# Altium schematic sheet 20-002314-00 pg2 Fixture Power.SchDoc
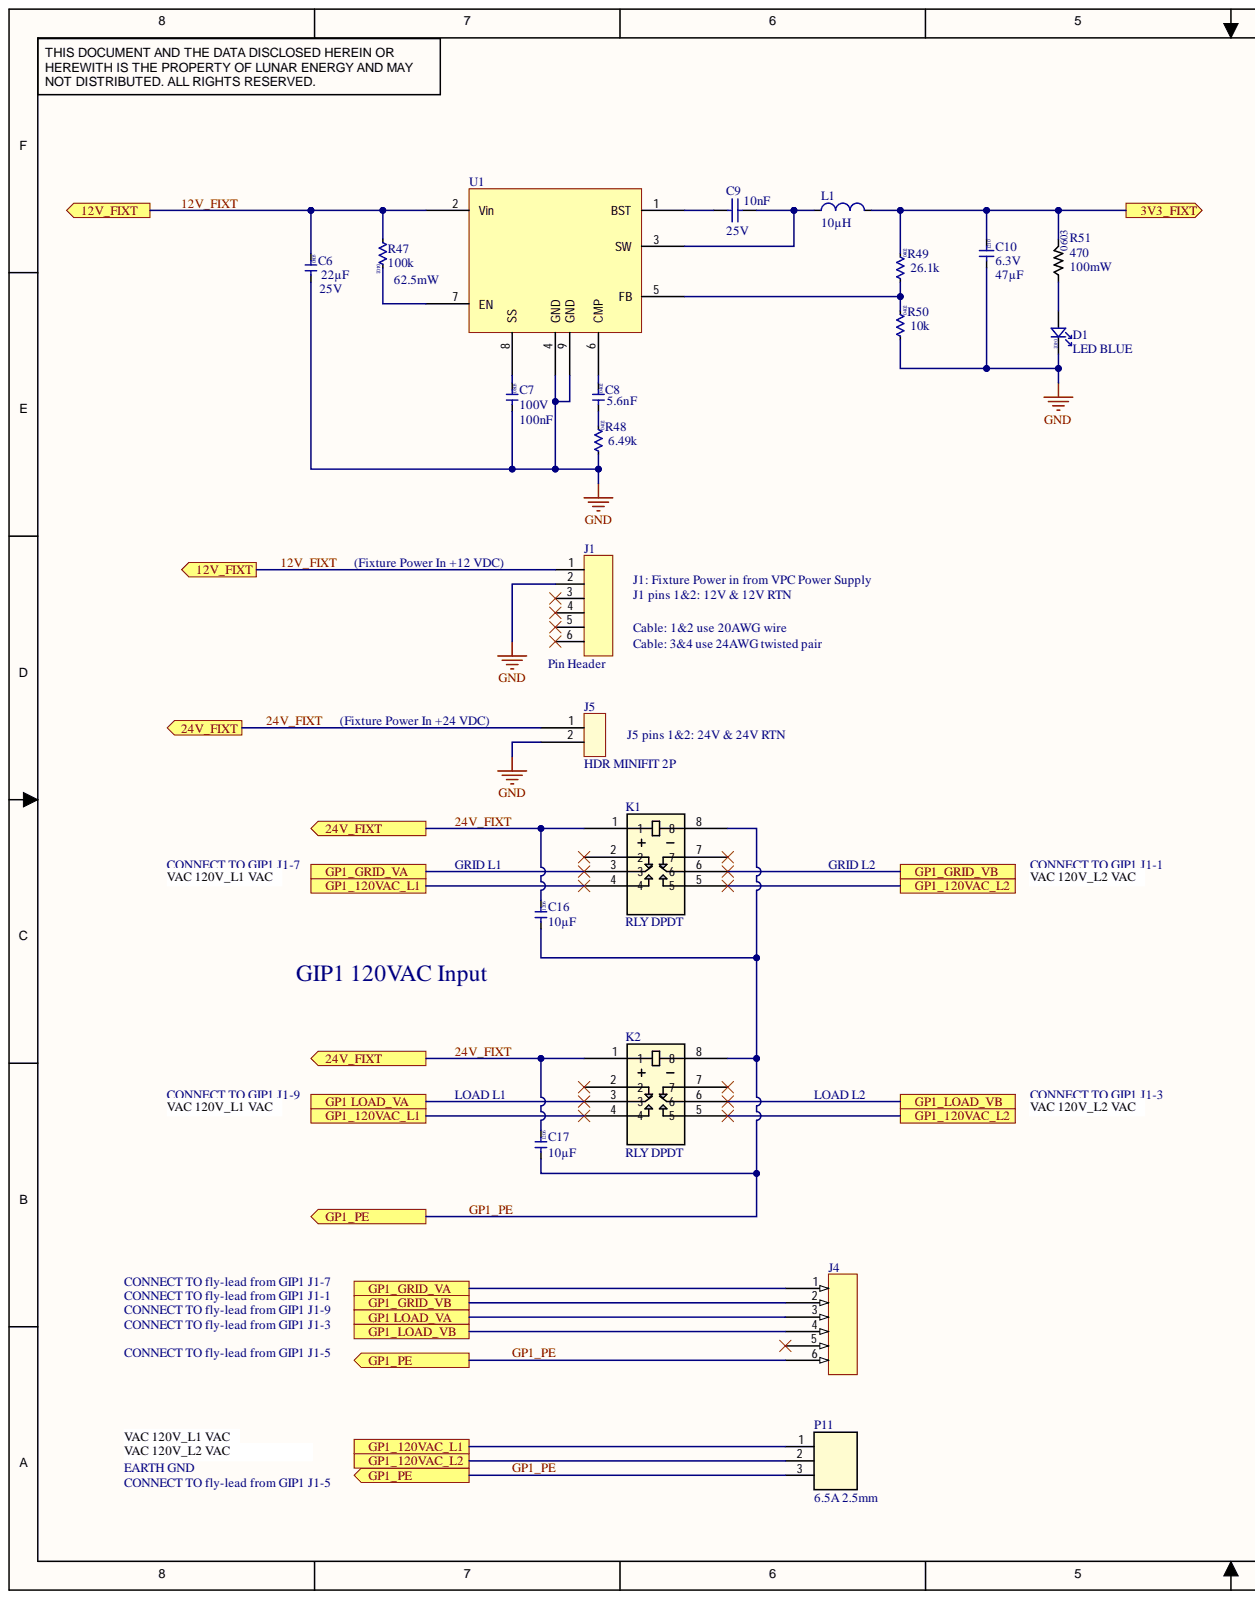
[diagram p0: 20-002314-00 pg2 Fixture Power.SchDoc, left]
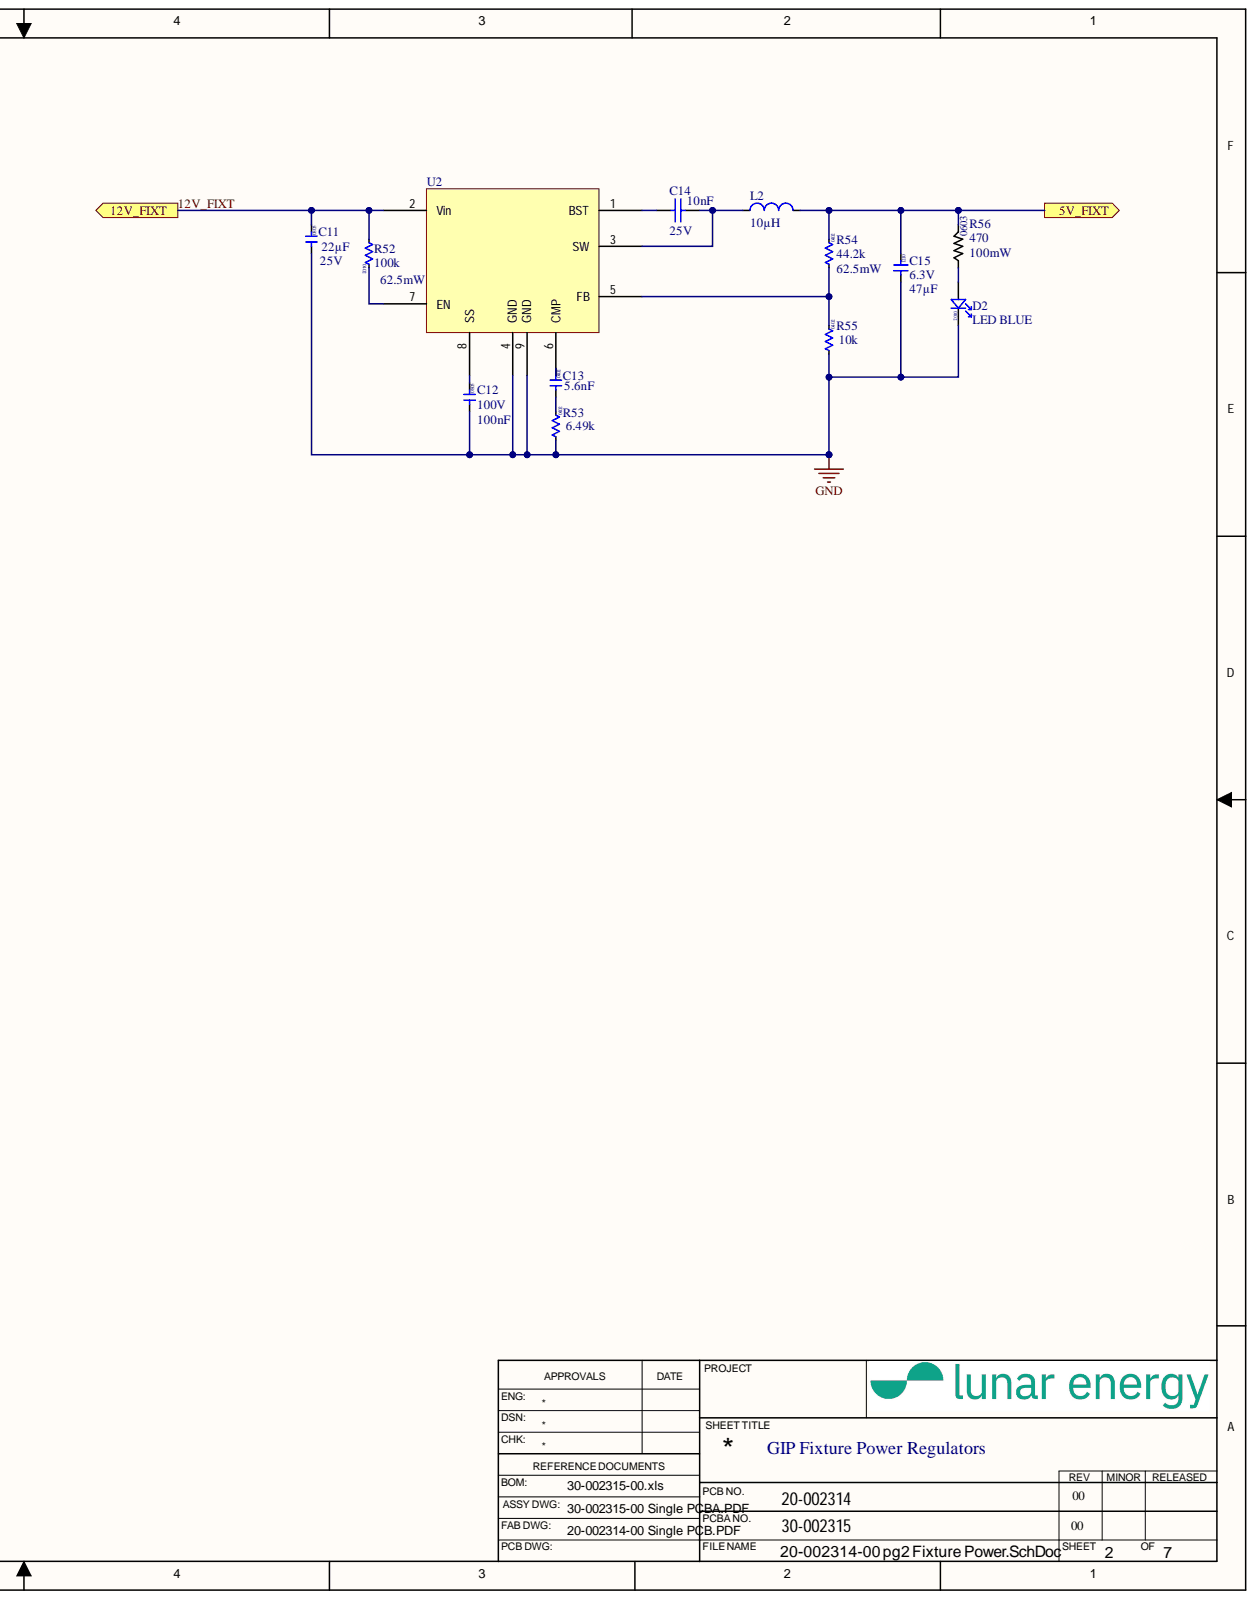
[diagram p1: 20-002314-00 pg2 Fixture Power.SchDoc, right]

## Parts
C6  value 22µF  Case/Package: 0805  Voltage Rating: 25V  [FP-CGA4J-IPC_A]  (hidden comment: GRM21BR61E226ME44L)
C7  value 100nF  Capacitance: 100nF  Rated DC Voltage (URdc): 100V  Size Code: 0805  [CAPC0805 - Lunar]  (hidden comment: GCE21BR72A104KA01L)
C8  value 5.6nF  Case/Package: 0402  [CAPC0402 - Lunar]  (hidden comment: CC0402JRX7R9BB562)
C9  value 10nF  Voltage Rating: 25V  [CAPC1005X55X10NL05]  (hidden comment: C1005X7R1E103M050BB)
C10  value 47µF  Case/Package: 1210  Rated DC Voltage (URdc): 6.3V  [FP-GCJ32E-0_4-0_3-MFG]  (hidden comment: GCJ32ER70J476KE01L)
C11  value 22µF  Case/Package: 0805  Voltage Rating: 25V  [FP-CGA4J-IPC_A]  (hidden comment: GRM21BR61E226ME44L)
C12  value 100nF  Capacitance: 100nF  Rated DC Voltage (URdc): 100V  Size Code: 0805  [CAPC0805 - Lunar]  (hidden comment: GCE21BR72A104KA01L)
C13  value 5.6nF  Case/Package: 0402  [CAPC0402 - Lunar]  (hidden comment: CC0402JRX7R9BB562)
C14  value 10nF  Voltage Rating: 25V  [CAPC1005X55X10NL05]  (hidden comment: C1005X7R1E103M050BB)
C15  value 47µF  Case/Package: 1210  Rated DC Voltage (URdc): 6.3V  [FP-GCJ32E-0_4-0_3-MFG]  (hidden comment: GCJ32ER70J476KE01L)
C16  value 10µF  Case/Package: 1206  [CAPC1206 - Lunar]  (hidden comment: CAP-1206-10U-A)
C17  value 10µF  Case/Package: 1206  [CAPC1206 - Lunar]  (hidden comment: CAP-1206-10U-A)
D1  Case/Package: 0402  Lunar Sym Name: LED BLUE  [APG1005PBC-T-5MAV-Footprint-1]  (hidden comment: D LED 0402 BLUE CLEAR SMD)
D2  Case/Package: 0402  Lunar Sym Name: LED BLUE  [APG1005PBC-T-5MAV-Footprint-1]  (hidden comment: D LED 0402 BLUE CLEAR SMD)
J1  Connector Type: Pin Header  [HDRV6W66P0X254_1X6_1524X249X838P]  (hidden comment: 0022284066)
J4  15-24-6061  [15-24-6061-Footprint-1]  (value not drawn)
J5  CONN HDR 2P MINIFIT JR VERT  [CONN HDR 2P MINIFIT JR VERT-Footprint-1]  (value not drawn)
K1  Lunar Sym Name: RLY DPDT  [G6K-2F-Y-TRDC24-Footprint-1]  (hidden comment: RELAY DPDT 1A 24VDC)
K2  Lunar Sym Name: RLY DPDT  [G6K-2F-Y-TRDC24-Footprint-1]  (hidden comment: RELAY DPDT 1A 24VDC)
L1  value 10µH  [NRS6028T100MMGKV-Footprint-1]  (hidden comment: L 10uH 1.9A 84.5mOHM SMD)
L2  value 10µH  [NRS6028T100MMGKV-Footprint-1]  (hidden comment: L 10uH 1.9A 84.5mOHM SMD)
P11  Lunar Sym Name: 6.5A 2.5mm  Max Current Rating: 6.5A  [1054311103-Footprint-1]  (hidden comment: Conn HDR 3P 6.5A VERT)
R47  value 100k  Case/Package: 0402  [RES 0402 - Lunar]  (hidden comment: RMCF0402FT100K)
R48  value 6.49k  Case/Package: 0402  [RES 0402 - Lunar]  (hidden comment: RC0402FR-076K49L)
R49  value 26.1k  Case/Package: 0402  [RES 0402 - Lunar]  (hidden comment: RC0402FR-0726K1L)
R50  value 10k  Case/Package: 0402  [RES 0402 - Lunar]  (hidden comment: RK73H1ELTP1002F)
R51  value 470  Case/Package: 0603  Rated Power Dissipation (P): 100mW  [RES0603 - Lunar]  (hidden comment: R 0603 470 OHM 1/10W)
R52  value 100k  Case/Package: 0402  [RES 0402 - Lunar]  (hidden comment: RMCF0402FT100K)
R53  value 6.49k  Case/Package: 0402  [RES 0402 - Lunar]  (hidden comment: RC0402FR-076K49L)
R54  value 44.2k  Case/Package: 0402  Power: 62.5mW  [RES 0402 - Lunar]  (hidden comment: RC0402FR-0744K2L)
R55  value 10k  Case/Package: 0402  [RES 0402 - Lunar]  (hidden comment: RK73H1ELTP1002F)
R56  value 470  Case/Package: 0603  Rated Power Dissipation (P): 100mW  [RES0603 - Lunar]  (hidden comment: R 0603 470 OHM 1/10W)
U1  AP6503SP-13  [PSO8]  (value not drawn)
U2  AP6503SP-13  [PSO8]  (value not drawn)

## Ports
3V3_FIXT, 5V_FIXT, 12V_FIXT, 24V_FIXT, GP1 LOAD_VA, GP1_120VAC_L1, GP1_120VAC_L2, GP1_GRID_VA, GP1_GRID_VB, GP1_LOAD_VB, GP1_PE

## Nets
GND: C6.2 C7.2 C10.2 C11.2 C12.2 C15.2 D1.1 D2.1 J1.2 J5.2 R48.1 R50.1 R53.1 R55.1 U1.4(GND) U1.9(GND) U2.4(GND) U2.9(GND)
3V3_FIXT: C10.1 L1.2 R49.2 R51.2 ; port 3V3_FIXT
5V_FIXT: C15.1 L2.2 R54.2 R56.2 ; port 5V_FIXT
12V_FIXT: C6.1 C11.1 J1.1 R47.1 R52.1 U1.2(Vin) U2.2(Vin) ; port 12V_FIXT
24V_FIXT: C16.1 C17.1 J5.1 K1.1 K2.1 ; port 24V_FIXT
GP1 LOAD_VA: J4.3 K2.3 ; port GP1 LOAD_VA
GP1_120VAC_L1: K1.4 K2.4 P11.1 ; port GP1_120VAC_L1
GP1_120VAC_L2: K1.5 K2.5 P11.2 ; port GP1_120VAC_L2
GP1_GRID_VA: J4.1 K1.3 ; port GP1_GRID_VA
GP1_GRID_VB: J4.2 K1.6 ; port GP1_GRID_VB
GP1_LOAD_VB: J4.4 K2.6 ; port GP1_LOAD_VB
GP1_PE: C16.2 C17.2 J4.6 K1.8 K2.8 P11.3 ; port GP1_PE
NetC7_1: C7.1 U1.8(SS)
NetC8_1: C8.1 U1.6(CMP)
NetC8_2: C8.2 R48.2
NetC9_1: C9.1 U1.1(BST)
NetC9_2: C9.2 L1.1 U1.3(SW)
NetC12_1: C12.1 U2.8(SS)
NetC13_1: C13.1 U2.6(CMP)
NetC13_2: C13.2 R53.2
NetC14_1: C14.1 U2.1(BST)
NetC14_2: C14.2 L2.1 U2.3(SW)
NetD1_2: D1.2 R51.1
NetD2_2: D2.2 R56.1
NetR47_2: R47.2 U1.7(EN)
NetR49_1: R49.1 R50.2 U1.5(FB)
NetR52_2: R52.2 U2.7(EN)
NetR54_1: R54.1 R55.2 U2.5(FB)

Not connected: J1 3 4 5 6; J4 5; K1 2 7; K2 2 7

## Library data of the parts
C6 C11 = CMP-001-00181-3: 22uF ±20% 25V X5R 0805; Lunar PN: 10-002476; Production Intent: YES; Component Kind: Standard; Max Operating Temperature: 85°C; Min Operating Temperature: -55°C; Tolerance: 20%; Library Name: Lunar Energy
C7 C12 = CMP-001-00050-4: Ceramic Capacitor, Multilayer, Ceramic, 100V, 10% +Tol, 10% -Tol, X7R, 15% TC, 0.1uF, Surface Mount, 0805; Manufacturer: Murata; Lunar PN: 10-001660; Multilayer: Yes; Number of Terminals/Pins: 2; Reference Standard: AEC-Q200; Capacitor Type: Ceramic; Component Kind: Standard; Dielectric Material: Ceramic; Manufacturer PN: GCE21BR72A104KA01L; Max Operating Temperature: 125°C; Min Operating Temperature: -55°C; Mount: Surface Mount; Negative Tolerance: 10%; Package Shape: Rectangular; Packaging: Tape and Reel; Positive Tolerance: 10%; Production Intent: YES; REACH SVHC: Yes; RoHS Compliant: Yes; Temperature Characteristics Code: X7R; Termination: Wraparound; Library Name: Lunar Energy
C8 C13 = CMP-001-00182-4: Cap Ceramic 0.0056uF 50V X7R 5% SMD 0402 125°C Paper T/R; Lunar PN: 10-002475; Production Intent: YES; Component Kind: Standard; Max Operating Temperature: 125°C; Min Operating Temperature: -55°C; Tolerance: 5%; Voltage Rating: 50V; Library Name: Lunar Energy
C9 C14 = CMP-001-00012-10: Chip Capacitor, 10 nF, +/- 15%, 25 V, -55 to 125 degC, 0402 (1005 Metric), RoHS, Tape and Reel; Manufacturer: TDK; AEC-Q: Yes; Lunar PN: 10-001716; Production Intent: NO; Tolerance: 20%; Type (Capacitors): X7R; Case Code (Metric): 1005; Case/Package: 0402; Component Kind: Standard; Component Type: Standard; ComponentLink1Description: Manufacturer URL; ComponentLink1URL: http://www.tdk.com/; ComponentLink2Description: Datasheet; ComponentLink2URL: https://ciiva.com/datasheet-click-20389836?src=ciiva&sig=78734acc; DatasheetVersion: 03/2015; Design Item ID: CMP-1663-00012-1; Height: 0.5 mm; Length: 1 mm; Manufacturer Part Number: C1005X7R1E103M050BB; Manufacturer PN: C1005X7R1E103M050BB; Max Operating Temperature: 125°C; Min Operating Temperature: -55°C; Mount: Surface Mount; Mounting Technology: Surface Mount; Package Quantity: 10000; PackageDescription: 2-Pin Surface Mount Device, Body 1 x 0.5 mm; Packaging: Tape and Reel; PartNumber: C1005X7R1E103M050BB; Pins: 2; RoHSCompliant: true; Voltage Rating (DC): 25V; Width: 0.5 mm; Library Name: Lunar Energy; Source: PC4-SODIMM_V200_RC_A1_20141015_ads.SCHLIB
C10 C15 = CMP-00019-4: Ceramic Capacitor, Multilayer, Ceramic, 6.3V, 10% +Tol, 10% -Tol, X7R, 15% TC, 47uF, Surface Mount, 1210; Manufacturer: Murata; Lunar PN: 10-001663; Multilayer: Yes; Notes: Soft Termination; Number of Terminals/Pins: 2; Reference Standard: AEC-Q200; Capacitance: 47uF; Capacitor Type: Ceramic; Component Kind: Standard; Dielectric Material: Ceramic; Height: 2.5mm; JESD-609 Code: e3; Length: 3.2mm; Manufacturer PN: GCJ32ER70J476KE01L; Max Operating Temperature: 125°C; Min Operating Temperature: -55°C; Mount: Surface Mount; Mounting Technology: SMT; Negative Tolerance: 10%; Package Shape: Rectangular; Package Style: SMTMeter; Packaging: Tape and Reel; Pins: 2; Positive Tolerance: 10%; Production Intent: YES; REACH SVHC: Yes; RoHS Compliant: Yes; Size Code: 1210; Temperature Characteristics Code: X7R; Terminal Finish: Nickel; Termination: Wraparound; Width: 2.5mm; Library Name: Lunar Energy
C16 C17 = CMP-001-00071-9: Ceramic Capacitor, Multilayer, Ceramic, 50V, 10% +Tol, 10% -Tol, X7R, 15% TC, 10uF, Surface Mount, 1206; Manufacturer: CAL-CHIP ELECTRONICS, INC.; Manufacturer PN: GMC31X7R106K50NT; Lunar PN: 10-001603; Production Intent: NO; Capacitor Type: Ceramic; Component Kind: Standard; Max Operating Temperature: 125°C; Min Operating Temperature: -55°C; Mounting Technology: Surface Mount; RoHS Compliant: Yes; Tolerance: 10%; Voltage Rating: 50V; Library Name: Lunar Energy
D1 D2 = CMP-007-00009-3: APG1005VBC-A1-5MAV, 1.0X0.5MM (0402) ULTRA THIN BLUE SMD LED 463nm; Manufacturer: Kingbright; AEC-Q: No; Forward Current: 5mA; Forward Voltage: 2.9V; Lunar PN: 10-002036; Max Power Dissipation: 16mW; Production Intent: YES; Color: Blue; Component Kind: Standard; Manufacturer PN: APG1005VBC-A1-5MAV; Max Operating Temperature: 85°C; Min Operating Temperature: -40°C; Mounting Technology: SMT; Nominal Vf: 2.9V; Pins: 2; RoHS Compliant: Yes; Library Name: Lunar Energy
J1 = CMP-002-00049-16: Connector, 6 Contact, 1 Row, Male, Straight, TH; Manufacturer: Molex; Gender: Plug; Lunar PN: 10-000845; Production Intent: YES; Component Kind: Standard; Manufacturer PN: 0022284066; Mounting Technology: Through-Hole; Pins: 6; RoHS Compliant: Yes; Library Name: Lunar Energy
J4 = CMP-002-00051-16: Rectangular Power Connector, 6 Contact(s), Male, Solder Terminal, Plug; Manufacturer: Molex; Manufacturer PN: 15246061; Connector Type: Power,Rectangular; Gender: Female; Lunar PN: 10-000758; Max Current Rating: 13A; Production Intent: YES; Component Kind: Standard; Max Operating Temperature: 105°C; Min Operating Temperature: -40°C; Mounting Technology: Through hole; Pins: 6; RoHS Compliant: Yes; Library Name: Lunar Energy
J5 = CMP-002-00129-1: 0039281023, Rectangular Power Connector, 2 Contact(s), Male, Solder Terminal; Manufacturer: Molex; Manufacturer Series: 5566; Connector Type: Power,Rectangular; DIN Conformance: No; Lunar PN: 10-001593; Lunar Sym Name: HDR MINIFIT 2P; Mating Information: MULTIPLE MATING PARTS AVAILABLE; Number of Contacts: 2; Option: General Purpose; Production Intent: YES; Component Kind: Standard; Contact Finish - Mating: TIN (35); Contact Finish - Termination: TIN (100); Contact Gender: Male; Contact Material: Brass; Empty Shell: No; Filter Feature: No; Flammability Rating: UL94 V-2; IEC Conformance: No; JESD-609 Code: e3; Manufacturer PN: 0039281023; MIL Conformance: No; Mixed Contacts: No; Mount: Board; REACH SVHC: Yes; RoHS Compliant: Yes; Shell Material: Polyamide; Termination: Solder; Library Name: Lunar Energy
K1 K2 = CMP-008-00005-3: G6K-2F-Y-TRDC24, Power/Signal Relay, 2 Form C, DPDT, Momentary, 0.005A (Coil), 36VDC (Coil), 100mW (Coil), 1A (Contact), 30VDC (Contact), DC Input, Random, AC/DC Output, Surface Mount-Straight; Manufacturer: Omron Electronics Inc-EMC Div; Lunar PN: 10-001589; Production Intent: YES; Component Kind: Standard; Manufacturer PN: G6K-2F-Y-TR DC24; Library Name: Lunar Energy
L1 L2 = CMP-006-00049-2: NRS6028T100MMGKV, General Purpose Inductor, 10uH, 20%, 1 Element, SMD, 2424; Manufacturer: Taiyo Yuden; AEC-Q: Yes; Lunar PN: 10-001925; Lunar Sym Name: IND 10uH 1.9A; Self Resonant Frequency: 20MHz; Component Kind: Standard; Current Rating: 1.9A; Manufacturer PN: NRS6028T100MMGKV; Max Operating Temperature: 125°C; Min Operating Temperature: -40°C; Production Intent: YES; RoHS Compliant: Yes; Tolerance: 20%; Library Name: Lunar Energy
P11 = CMP-002-00091-5: 1054311103 Rectangular Power Connector; Manufacturer: Molex; Lunar PN: 10-000992; Production Intent: YES; Component Kind: Standard; Manufacturer PN: 1054311103; Mounting Technology: SMT; Pins: 5; Supplier: Digikey; Supplier PN: WM26074CT-ND; Library Name: Lunar Energy
R47 R52 = CMP-009-00032-9: Fixed Resistor, Metal Glaze/thick Film, 0.063W, 100000ohm, 50V, 1% +/-Tol, 100ppm/Cel, Surface Mount, 0402; Manufacturer: Stackpole Electronics Inc; AEC-Q: Yes; Lunar PN: 10-000645; Power: 62.5mW; Temperature Coefficient: 100ppm/°C; Component Kind: Standard; Manufacturer PN: RMCF0402FT100K; Max Operating Temperature: 155°C; Min Operating Temperature: -55°C; Mounting Technology: SMT; Pins: 2; Production Intent: YES; RoHS Compliant: Yes; Tolerance: 1%; Library Name: Lunar Energy
R48 R53 = CMP-009-00194-3: 6490Ω ± 1.00% 0.0625W ± 100ppm/℃ 0402; AEC-Q: Yes; Lunar PN: 10-002503; Power: 62.5mW; Temperature Coefficient: 100ppm/°C; Tolerance: 1%; Voltage Rating: 50V; Component Kind: Standard; Max Operating Temperature: 155°C; Min Operating Temperature: -55°C; Mounting Technology: SMT; Pins: 2; Production Intent: YES; RoHS Compliant: Yes; Library Name: Lunar Energy
R49 = CMP-009-00195-2: 26100Ω ± 1.00% 0.0625W ± 100ppm/℃ 0402; AEC-Q: Yes; Lunar PN: 10-002505; Power: 62.5mW; Temperature Coefficient: 100ppm/°C; Tolerance: 1%; Voltage Rating: 50V; Component Kind: Standard; Max Operating Temperature: 155°C; Min Operating Temperature: -55°C; Mounting Technology: SMT; Pins: 2; Production Intent: YES; RoHS Compliant: Yes; Library Name: Lunar Energy
R50 R55 = CMP-009-00192-2: Res Thick Film 0402 10K Ohm 1% 0.1W(1/10W) ±100ppm/C Pad SMD Automotive T/R; AEC-Q: Yes; Lunar PN: 10-002501; Power: 62.5mW; Temperature Coefficient: 100ppm/°C; Voltage Rating: 50V; Component Kind: Standard; Max Operating Temperature: 155°C; Min Operating Temperature: -55°C; Mounting Technology: SMT; Pins: 2; Production Intent: YES; RoHS Compliant: No; Tolerance: 1%; Library Name: Lunar Energy
R51 R56 = CMP-009-00113-10: CRCW0603470RFKTA  Fixed Resistor, Metal Glaze/  thick Film, 0.1W, 470ohm, 75V, 1% +/-Tol, 100ppm/Cel, Surface Mount, 0603; Lunar PN: 10-001001; Number of Terminals/Pins: 2; Package Height: 0.45mm; Package Length: 1.55mm; Package Width: 0.85mm; Production Intent: YES; Rated Temperature: 70°C; Reference Standard: AEC-Q200; Resistance: 470R; Temperature Coefficient: 100ppm/°C; Component Kind: Standard; Construction: Chip; JESD-609 Code: e0; Lunar Create Date: 09/06/2021; Lunar Datasheet Depository Path: https://drive.google.com/drive/folders/1-FsjtxcpTQOCw983N1hjati_CHapLhla; Manufacturer PN: CRCW0603470RFKTA; Max Operating Temperature: 155°C; Min Operating Temperature: -55°C; Mount: Surface Mount; Package Shape: Rectangular; Package Style: SMTMeter; Packaging: Tape and Reel; REACH SVHC: No SVHC; Resistor Type: FIXED RESISTOR; RoHS Compliant: No; Size Code: 0603; Supplier: Digikey; Supplier PN: 541-CRCW0603470RFKTACT-ND; Supplier Web Page URL: https://www.digikey.com/en/products/detail/vishay-dale/CRCW0603470RFKTA/1222127?s=N4IgTCBcDaIMICU4HUAMA2VBmALAdlQQDEBpAFQEEQBdAXyA; Technology: METAL GLAZE/THICK FILM; Terminal Finish: Nickel; Termination: Wraparound; Tolerance: 1%; Working Voltage: 75V; Library Name: Lunar Energy
R54 = CMP-009-00196-2: 44200Ω ± 1.00% 0.0625W ± 100ppm/℃ 0402; AEC-Q: Yes; Lunar PN: 10-002504; Temperature Coefficient: 100ppm/°C; Tolerance: 1%; Voltage Rating: 50V; Component Kind: Standard; Max Operating Temperature: 155°C; Min Operating Temperature: -55°C; Mounting Technology: SMT; Pins: 2; Production Intent: YES; RoHS Compliant: Yes; Library Name: Lunar Energy
U1 U2 = CMP-009-000002-2: 2A/3A Adjustable Buck Regulator; Manufacturer: Diodes Incorporated; Lunar PN: 10-002472; Production Intent: YES; Component Kind: Standard; Design Item ID: AP6503SP-13; Manufacturer PN: AP6503SP-13; Source: Home Controller Motherboard.SCHLIB; Library Name: Lunar Energy
